# Altium schematic sheet Digital PSU.SchDoc("Digital PSU")
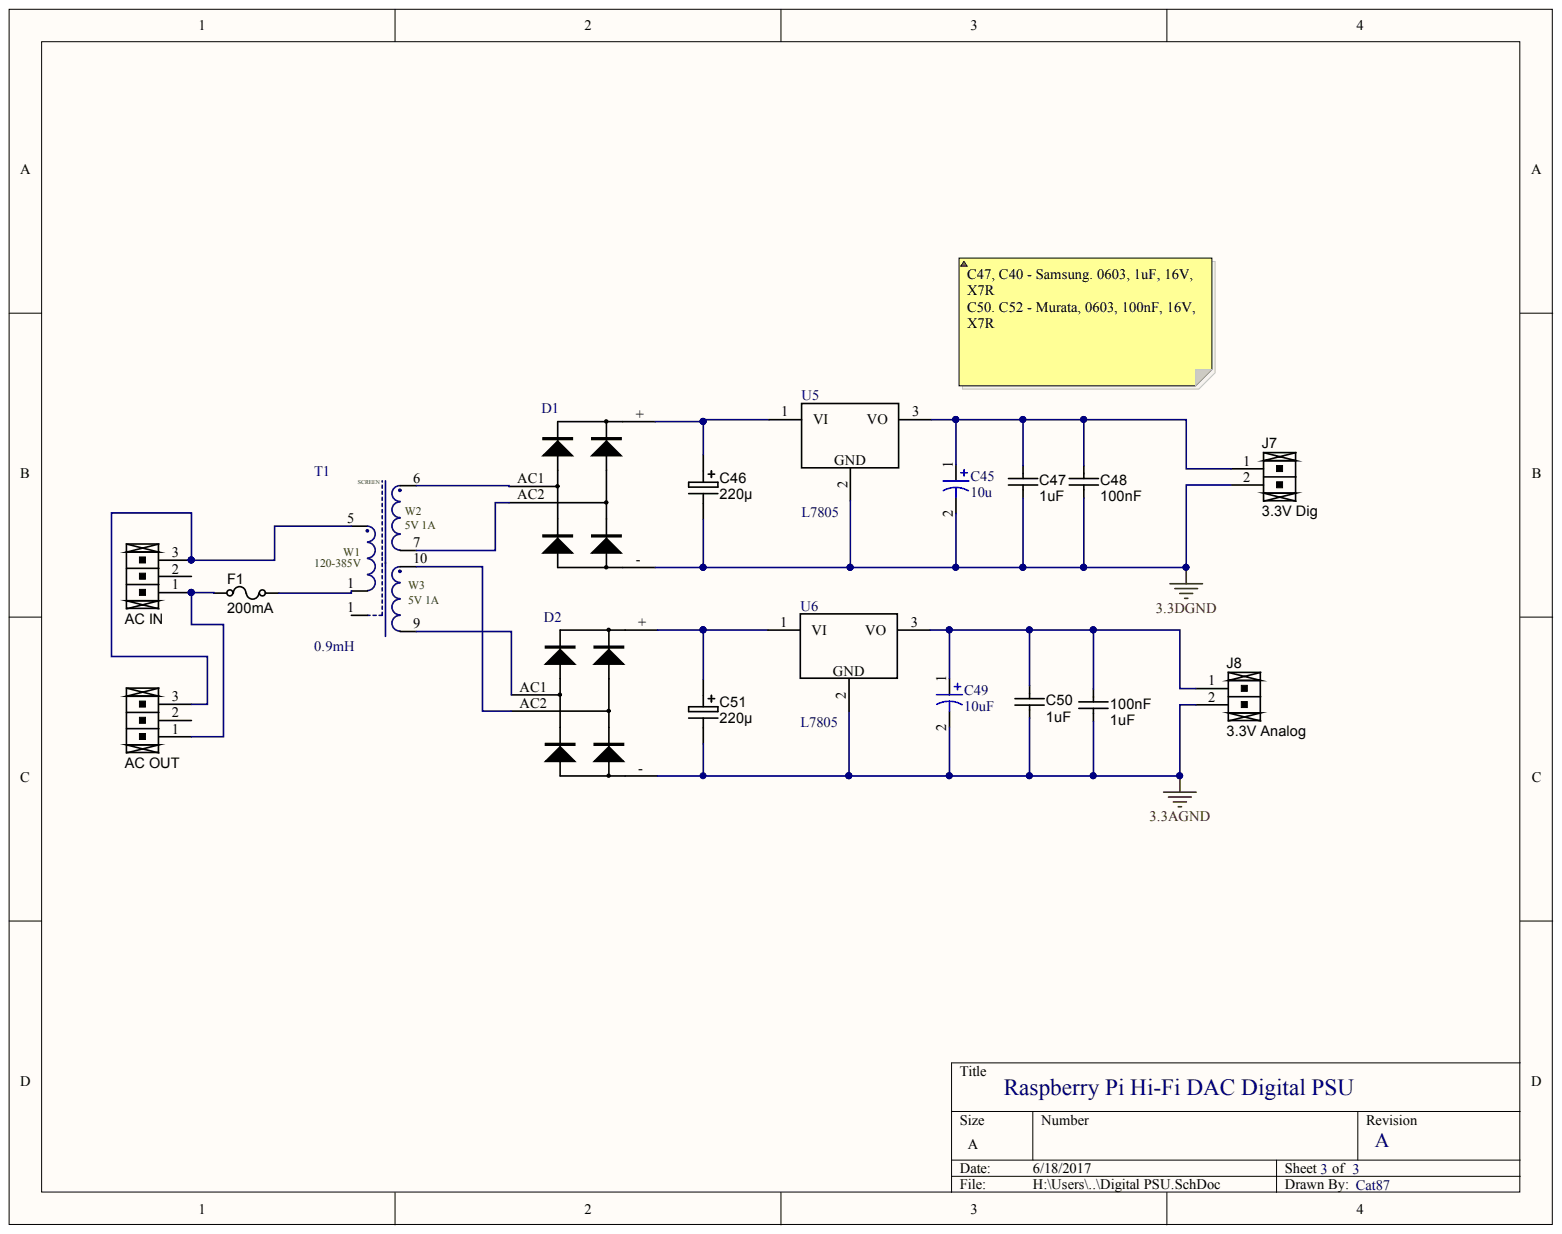
[diagram p0: Digital PSU.SchDoc]

## Parts
100nF  value 1uF  (hidden comment: approved sub OK)
AC_IN  3P_TERM BLOCK HEADER  (value not drawn)
AC_OUT  3P_TERM BLOCK HEADER  (value not drawn)
C45  10u
C46  220µ
C47  value 1uF  (hidden comment: approved sub OK)
C48  value 100nF  (hidden comment: approved sub OK)
C49  10uF
C50  value 1uF  (hidden comment: approved sub OK)
C51  220µ
D1  (no value)
D2  (no value)
F1  value 200mA  (hidden comment: Slow-blow)
J7  Manufacturer Part Number 1: 3.3V Dig  (hidden comment: 2P_TERM_BLOCK_HEADER)
J8  Manufacturer Part Number 1: 3.3V Analog  (hidden comment: 2P_TERM_BLOCK_HEADER)
T1  0.9mH
U5  L7805
U6  L7805

## Nets
3.3AGND: 100nF.2 C49.2 C50.2 C51.2 D2.- J8.2 U6.2
3.3DGND: C45.2 C46.2 C47.2 C48.2 D1.- J7.2 U5.2
Net100nF_1: 100nF.1 C49.1 C50.1 J8.1 U6.3
NetAC IN_1: AC_IN.1 AC_OUT.1 F1.1
NetAC IN_3: AC_IN.3 AC_OUT.3 T1.5
NetC45_1: C45.1 C47.1 C48.1 J7.1 U5.3
NetC46_1: C46.1 D1.+ U5.1
NetC51_1: C51.1 D2.+ U6.1
NetD1_AC1: D1.AC1 T1.6
NetD1_AC2: D1.AC2 T1.7
NetD2_AC1: D2.AC1 T1.9
NetD2_AC2: D2.AC2 T1.10
NetF1_2: F1.2 T1.1

Not connected: AC_IN 2; AC_OUT 2

## Library data of the parts
100nF = (no symbol name): Manufacturer 1: AVX Corporation; Manufacturer Part Number 1: 0603ZD225KAT2A; Tolerance: ±10%; Ratings: 10V; X5R; SCDType: spec; Package / Case: 0603 (1608 metric); Material: ceramic; Supplier 1: Digi-Key; Supplier Part Number 1: 478-6212-1-ND; ComponentLink1URL: http://www.avx.com/docs/Catalogs/cx5r.pdf; ComponentLink1Description: http://www.avx.com/docs/Catalogs/cx5r.pdf
AC_IN AC_OUT = (no symbol name): Manufacturer 1: TE Connectivity; Manufacturer Part Number 1: 284517-3; Pitch: 0.150" (3.81mm); Voltage: 300V; Mating Connector: 284507-3; Value: 3 position; Positions Per Level: 3; SCDType: undef; Category: Connectors; Mounting Type: Through Hole; Terminal Block Type: Header, Male Pins, Shrouded (4 Side); Package / Case: Side Entry; 3.81mm pitch; Supplier 1: Digi-Key; Supplier Part Number 1: A98411-ND; ComponentLink1URL: http://www.te.com/commerce/DocumentDelivery/DDEController?Action=srchrtrv&DocNm=284517&DocType=Customer+Drawing&DocLang=English; ComponentLink1Description: http://www.te.com/commerce/DocumentDelivery/DDEController?Action=srchrtrv&DocNm=284517&DocType=Customer+Drawing&DocLang=English
C45 C49 = (no symbol name): Manufacturer: Wurth Elektronik; partNo: 865080443009; E-mail: libraries@we-online.com; Capacitance: 68 μF; Characteristics: Aluminum Electrolytic Capacitor;Recommended soldering: Reflow;Operating temperature: –55 °C to +105 °C;Low impedance product series;Load life: 2000 h @ +105 °C; ComponentLink1Description: Manufacturer; ComponentLink1URL: http://www.we-online.com; ComponentLink2Description: Datasheet; ComponentLink2URL: http://katalog.we-online.de/pbs/datasheet/865080443009.pdf; Created Date: 5/13/2015; Application: Energy storage or high frequency filtering in the low voltage circuit; used as power supply filtering in general switching power supply, DC-DC converter, AC-DC converter, conversion adapter, charger, TV, VCR, DC motor circuit filter, digital audio, digital TV, digital camera, digital video camera, satellite receiver, equipment control panel and etc.; Created by: Karrer Zheng
C46 C51 = (no symbol name): Manufacturer 1: NICHICON; Manufacturer Part Number 1: UHM1C471MPD6; Ratings: 16V; 105°C; Tolerance: ±20%; Material: Al Electrolytic; SCDType: undef; Package / Case: Radial; Supplier 1: Newark; Supplier Part Number 1: 54K8151; Manufacturer 2: JD; Manufacturer Part Number 2: GT470UF16V_10x12mm; Value: 220µ
C47 C48 C50 = (no symbol name): Manufacturer 1: AVX Corporation; Manufacturer Part Number 1: 0603ZD225KAT2A; Tolerance: ±10%; Ratings: 10V; X5R; Material: ceramic; SCDType: spec; Package / Case: 0603 (1608 metric); Supplier 1: Digi-Key; Supplier Part Number 1: 478-6212-1-ND; ComponentLink1URL: http://www.avx.com/docs/Catalogs/cx5r.pdf; ComponentLink1Description: http://www.avx.com/docs/Catalogs/cx5r.pdf
F1 = (no symbol name): Manufacturer 1: Cooper Bussmann; Manufacturer Part Number 1: SR-5-200MA-BK; Ratings: 200mA; 250VAC; slow-blow; SCDType: source; Package / Case: Radial 5.08mm pitch; Supplier 1: Mouser; Supplier Part Number 1: 504-SR-5-200MA-BK
J7 J8 = (no symbol name): Manufacturer 1: TE Connectivity; Pitch: 0.150" (3.81mm); Voltage: 300V; Positions Per Level: 2; Mating Connector: 284507-2; SCDType: undef; Category: Connectors; Mounting Type: Through Hole; Terminal Block Type: Header, Male Pins, Right-angle, Shrouded (4 Side); Package / Case: Header; 3.81mm pitch; Supplier 1: Digi-Key; Supplier Part Number 1: A98410-ND
T1 = (no symbol name): PartNo: 749118215; Manufacturer: Würth Elektronik eiSos GmbH; Turns Ratio: 19:1:1; Input Voltage (AC): 85-265; Output Voltage (DC): 5; Output Current (A): 0.6; Inductance: 0.9mH; ComponentLink1Description: Manufacturer; ComponentLink1URL: http://www.we-online.de; Created Date: OCT 2013; ComponentLink2Description: Datasheet; ComponentLink2URL: http://www.we-online.de/katalog/media/pdf/749118215.pdf; Created by: Otto Christin
D1 D2 U5 U6 = (no symbol name)
  U5 U6: Supplier 1: Digi-Key; Supplier Part Number 1: 497-7255-6-ND
